# Altium schematic sheet digital.SchDoc
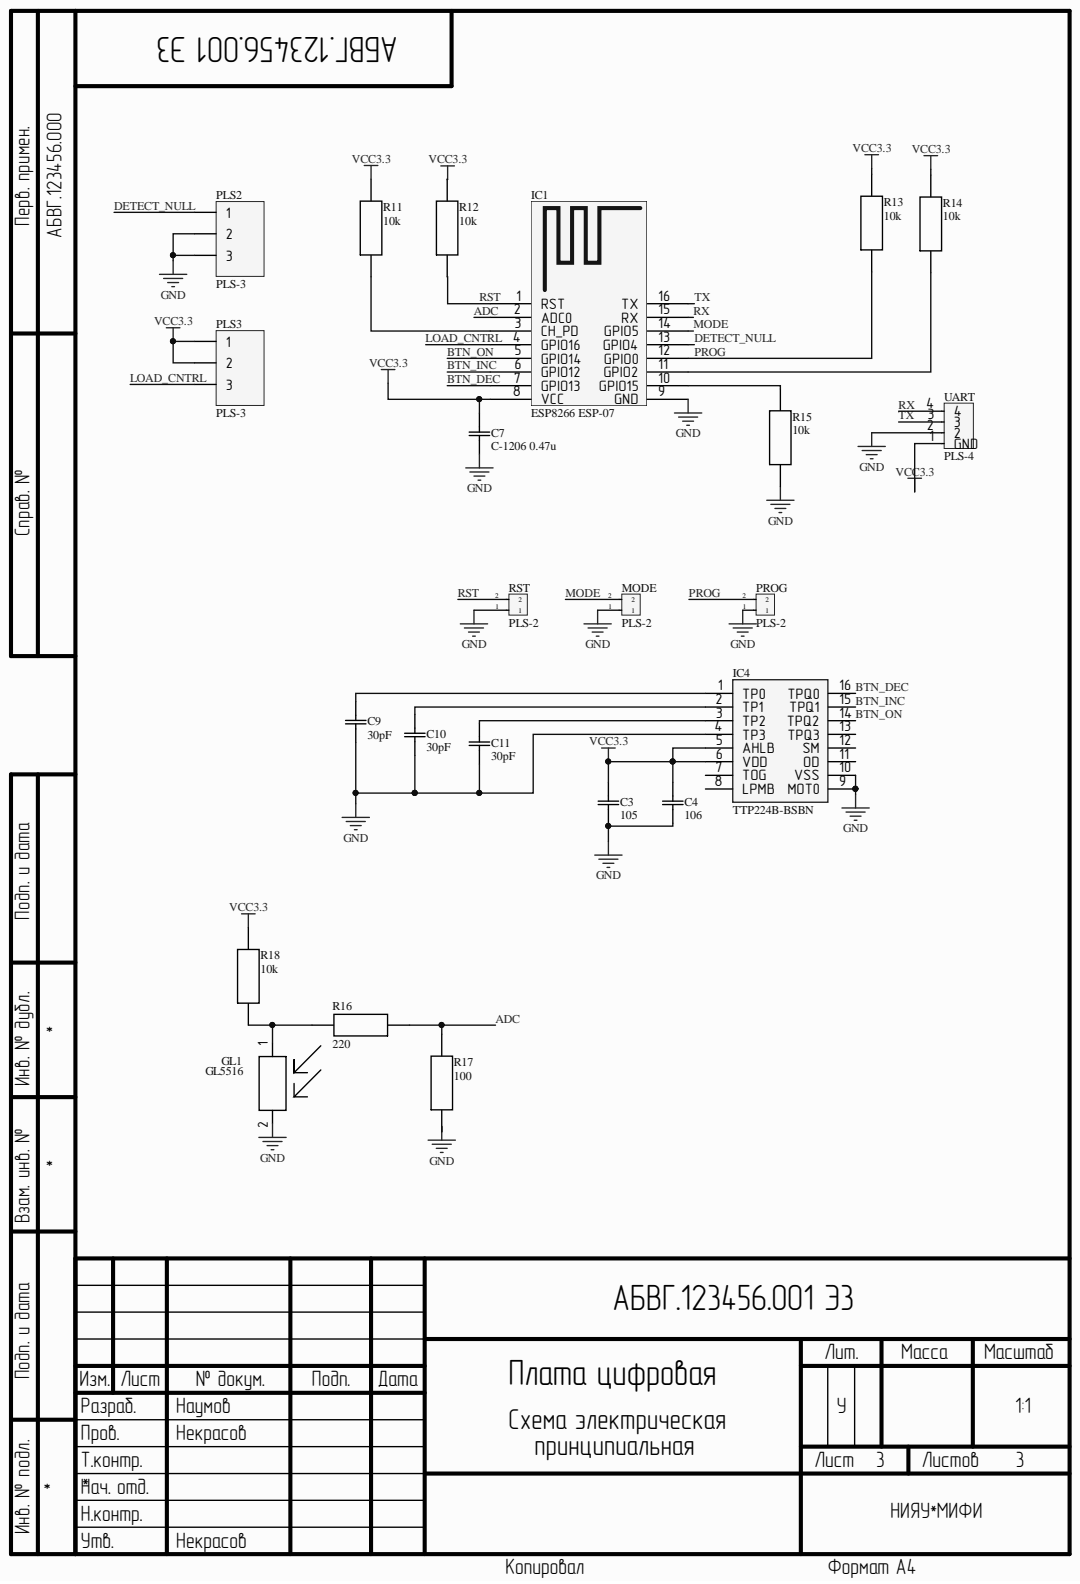
[diagram p0: digital.SchDoc]

## Parts
C3  105  [G-21_MUR]
C4  106  [G-21_MUR]
C7  C-1206 0.47u  [CAP_X7R_1206_AVX]
C9  30pF  [G-21_MUR]
C10  30pF  [G-21_MUR]
C11  30pF  [G-21_MUR]
GL1  GL5516  [GL5516]
IC1  ESP8266 ESP-07  [ESP8266 ESP-07]
IC4  TTP224B-BSBN  [SOP64P600X175-16N]
MODE  PLS-2  [PLS-2]
PLS2  PLS-3  [PLS-3]
PLS3  PLS-3  [PLS-3]
PROG  PLS-2  [PLS-2]
R11  10k  [G-21_MUR]
R12  10k  [G-21_MUR]
R13  10k  [G-21_MUR]
R14  10k  [G-21_MUR]
R15  10k  [G-21_MUR]
R16  220  [G-21_MUR]
R17  100  [G-21_MUR]
R18  10k  [G-21_MUR]
RST  PLS-2  [PLS-2]
UART  PLS-4  [PLS-4]

## Nets
GND: C3.1 C4.1 C7.1 C9.1 C10.1 C11.1 GL1.2 IC1.9(GND) IC4.4(TP3) IC4.9(MOT0) IC4.10(VSS) MODE.1 PLS2.2 PLS2.3 PROG.1 R15.1 R17.1 RST.1 UART.2
VCC3.3: C3.2 C4.2 C7.2 IC1.8(VCC) IC4.5(AHLB) IC4.6(VDD) PLS3.1 PLS3.2 R11.2 R12.2 R13.2 R14.2 R18.2 UART.1
ADC: IC1.2(ADC0) R16.1 R17.2
BTN_DEC: IC1.7(GPIO13) IC4.16(TPQ0)
BTN_INC: IC1.6(GPIO12) IC4.15(TPQ1)
BTN_ON: IC1.5(GPIO14) IC4.14(TPQ2)
DETECT_NULL: IC1.13(GPIO4) PLS2.1
LOAD_CNTRL: IC1.4(GPIO16) PLS3.3
MODE: IC1.14(GPIO5) MODE.2
PROG: IC1.12(GPIO0) PROG.2 R13.1
RST: IC1.1(RST) R12.1 RST.2
RX: IC1.15(RX) UART.4
TX: IC1.16(TX) UART.3
NetC9_2: C9.2 IC4.1(TP0)
NetC10_2: C10.2 IC4.2(TP1)
NetC11_2: C11.2 IC4.3(TP2)
NetGL1_1: GL1.1 R16.2 R18.1
NetIC1_3: IC1.3(CH_PD) R11.1
NetIC1_10: IC1.10(GPIO15) R15.2
NetIC1_11: IC1.11(GPIO2) R14.1
NetIC4_7: IC4.7(TOG)
NetIC4_8: IC4.8(LPMB)
NetIC4_11: IC4.11(OD)
NetIC4_12: IC4.12(SM)
NetIC4_13: IC4.13(TPQ3)

## Library data of the parts
C3 C4 C9-C11 = cap0805: Manufacturer_Part_Number: GRM21BR71H224KA01L; SourceLibrary: Murata_2014-12-31; Type: DEV; Vendor: Murata; Component Kind: Standard; Description: cap0805; RefDes: RefDes; Copyright: Copyright (C) 2015 Accelerated Designs. All rights reserved; Library Name: diplom.IntLib
C7 = C-1206: No Description Available; Manufacturer_Part_Number: 12061C104KAT2A; Built_By: EMA_UL_Team; SourceLibrary: AVX_2012-08-08; Type: DEV; Vendor: AVX Corporation; Component Kind: Standard; RefDes: RefDes; Copyright: Copyright (C) 2018 Accelerated Designs. All rights reserved; Library Name: diplom.IntLib
IC4 = TTP224B-BSBN: Integrated Circuit; Manufacturer_Name: TONTEK; Component Kind: Standard; Datasheet Link: https://datasheet.lcsc.com/szlcsc/Tontek-Design-Tech-TTP224B-BSBN_C94149.pdf; Height: 1.75mm; Manufacturer_Part_Number: TTP224B-BSBN; Library Name: diplom.IntLib
R11-R18 = res0805: Resistor 0805; Component Kind: Standard; Library Name: diplom.IntLib
GL1 = GL5516: Component Kind: Standard; Library Name: diplom.IntLib
IC1 = ESP8266 ESP-07: Component Kind: Standard; Description: ESP8266 ESP-07; Library Name: diplom.IntLib
MODE PROG RST = PLS-2: Component Kind: Standard; Library Name: diplom.IntLib
PLS2 PLS3 = PLS-3: Component Kind: Standard; Library Name: diplom.IntLib
UART = PLS-4: Component Kind: Standard; Library Name: diplom.IntLib
